# Altium schematic sheet MCU_AND_PW3.SchDoc
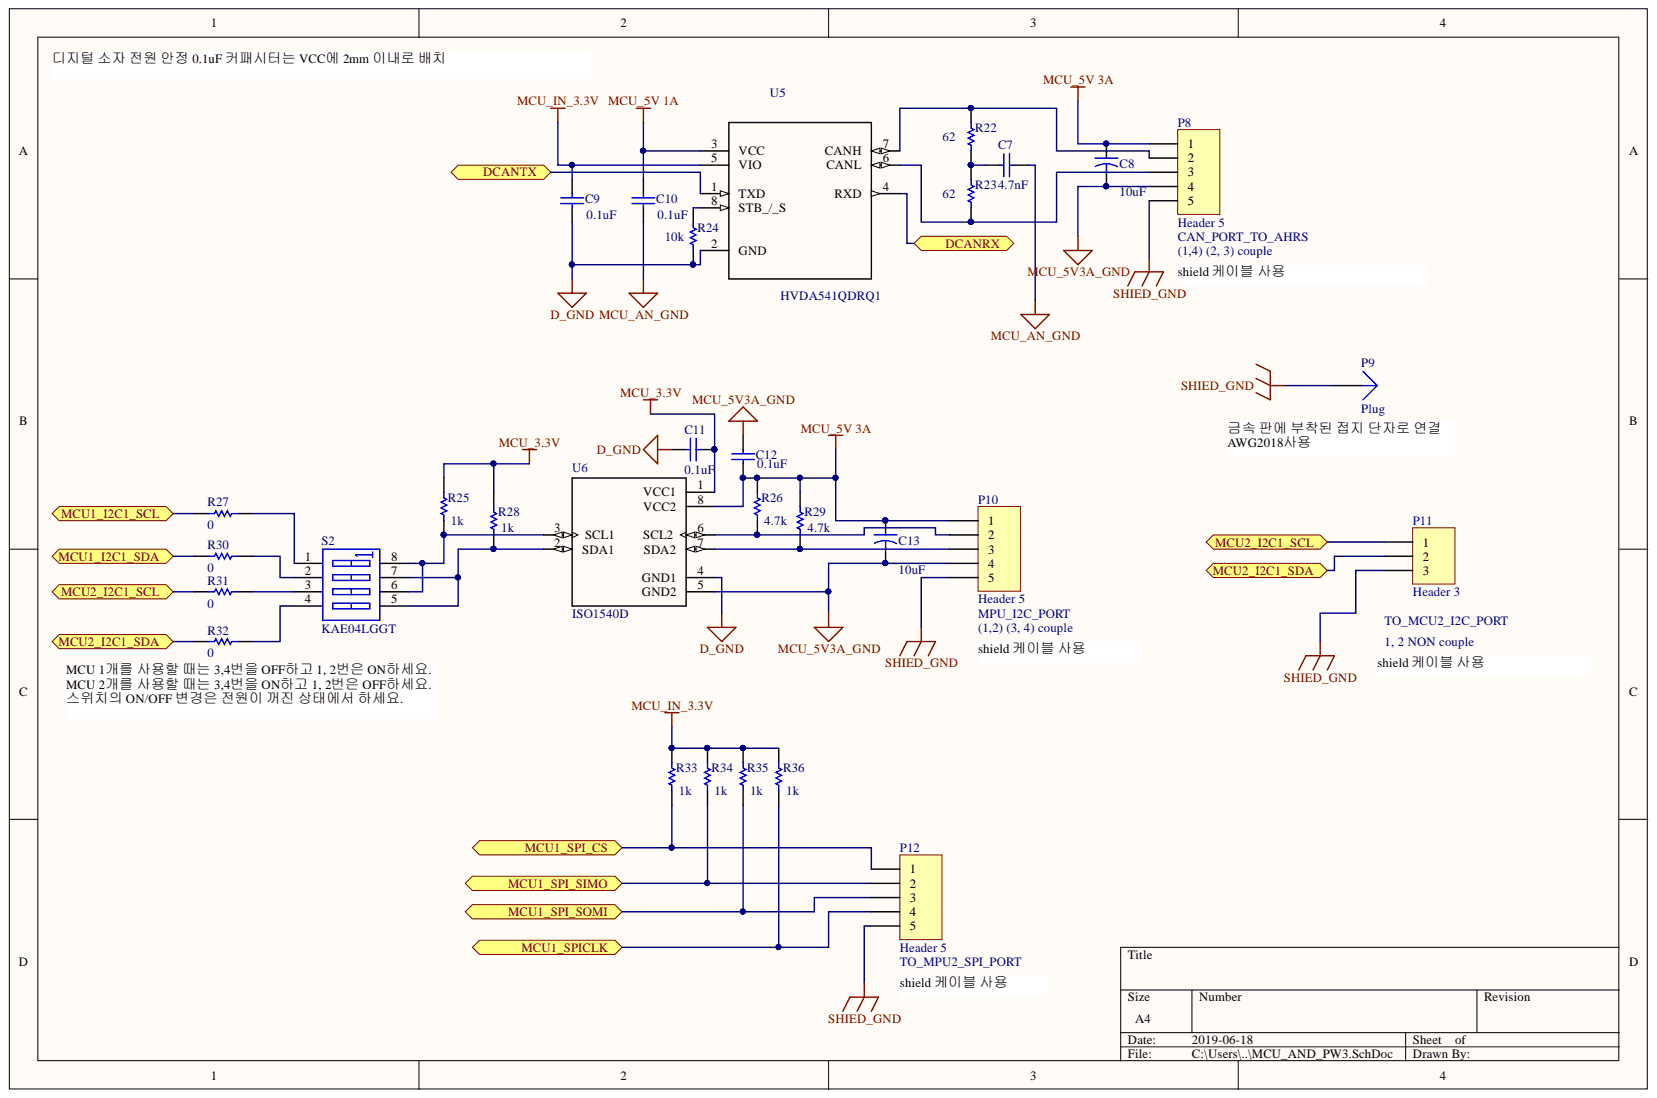
[diagram p0: MCU_AND_PW3.SchDoc]

## Parts
C7  value 4.7nF  [RAD-0.3]  (hidden comment: Cap)
C8  value 10uF  [CAPR5-4X5]  (hidden comment: Cap2)
C9  value 0.1uF  [RAD-0.3]  (hidden comment: Cap)
C10  value 0.1uF  [RAD-0.3]  (hidden comment: Cap)
C11  value 0.1uF  [RAD-0.3]  (hidden comment: Cap)
C12  value 0.1uF  [RAD-0.3]  (hidden comment: Cap)
C13  value 10uF  [CAPR5-4X5]  (hidden comment: Cap2)
P8  Header 5  [HDR1X5]
P9  Plug  [PIN1]
P10  Header 5  [HDR1X5]
P11  Header 3  [HDR1X3]
P12  Header 5  [HDR1X5]
R22  value 62  [AXIAL-0.3]  (hidden comment: Res1)
R23  value 62  [AXIAL-0.3]  (hidden comment: Res1)
R24  value 10k  [AXIAL-0.3]  (hidden comment: Res1)
R25  value 1k  [AXIAL-0.3]  (hidden comment: Res1)
R26  value 4.7k  [AXIAL-0.3]  (hidden comment: Res1)
R27  value 0  [AXIAL-0.3]  (hidden comment: Res1)
R28  value 1k  [AXIAL-0.3]  (hidden comment: Res1)
R29  value 4.7k  [AXIAL-0.3]  (hidden comment: Res1)
R30  value 0  [AXIAL-0.3]  (hidden comment: Res1)
R31  value 0  [AXIAL-0.3]  (hidden comment: Res1)
R32  value 0  [AXIAL-0.3]  (hidden comment: Res1)
R33  value 1k  [AXIAL-0.3]  (hidden comment: Res1)
R34  value 1k  [AXIAL-0.3]  (hidden comment: Res1)
R35  value 1k  [AXIAL-0.3]  (hidden comment: Res1)
R36  value 1k  [AXIAL-0.3]  (hidden comment: Res1)
S2  KAE04LGGT  [SO8_N]
U5  HVDA541QDRQ1  [*SOIC127P600X175-8N]
U6  ISO1540D  [*SOIC127P600X175-8N]

## Ports
DCANRX, DCANTX, MCU1_I2C1_SCL, MCU1_I2C1_SDA, MCU1_SPI_CS, MCU1_SPI_SIMO, MCU1_SPI_SOMI, MCU1_SPICLK, MCU2_I2C1_SCL, MCU2_I2C1_SDA

## Nets
D_GND: C9.1 C11.2 R24.1 U5.2(GND) U6.4(GND1)
MCU_3.3V: C11.1 R25.2 R28.2 U6.1(VCC1)
MCU_5V3A_GND: C8.2 C12.2 C13.2 P8.4 P10.4 U6.5(GND2)
MCU_5V 1A: C10.2 U5.3(VCC)
MCU_5V 3A: C8.1 C12.1 C13.1 P8.1 P10.1 R26.2 R29.2 U6.8(VCC2)
MCU_AN_GND: C7.1 C10.1
MCU_IN_3.3V: C9.2 R33.2 R34.2 R35.2 R36.2 U5.5(VIO)
SHIED_GND: P8.5 P9.1 P10.5 P11.3 P12.5
DCANRX: U5.4(RXD) ; port DCANRX
DCANTX: U5.1(TXD) ; port DCANTX
MCU1_I2C1_SCL: R27.2 ; port MCU1_I2C1_SCL
MCU1_I2C1_SDA: R30.2 ; port MCU1_I2C1_SDA
MCU1_SPI_CS: P12.1 R33.1 ; port MCU1_SPI_CS
MCU1_SPI_SIMO: P12.2 R34.1 ; port MCU1_SPI_SIMO
MCU1_SPI_SOMI: P12.3 R35.1 ; port MCU1_SPI_SOMI
MCU1_SPICLK: P12.4 R36.1 ; port MCU1_SPICLK
MCU2_I2C1_SCL: P11.1 R31.2 ; port MCU2_I2C1_SCL
MCU2_I2C1_SDA: P11.2 R32.2 ; port MCU2_I2C1_SDA
NetC7_2: C7.2 R22.1 R23.2
NetP8_2: P8.2 R22.2 U5.7(CANH)
NetP8_3: P8.3 R23.1 U5.6(CANL)
NetP10_2: P10.2 R26.1 U6.6(SCL2)
NetP10_3: P10.3 R29.1 U6.7(SDA2)
NetR24_2: R24.2 U5.8(STB_/_S)
NetR25_1: R25.1 S2.6 S2.8 U6.3(SCL1)
NetR27_1: R27.1 S2.1
NetR28_1: R28.1 S2.5 S2.7 U6.2(SDA1)
NetR30_1: R30.1 S2.2
NetR31_1: R31.1 S2.3
NetR32_1: R32.1 S2.4

## Library data of the parts
C7 C9-C12 = Cap: Capacitor; Component Kind: Standard; LatestRevisionDate: 17-Jul-2002; LatestRevisionNote: Re-released for DXP Platform.; Library Name: Miscellaneous Devices.IntLib; PackageDescription: Radial Cap, Thru-Hole; 2 Leads; 0.3 in Pin Spacing; PackageReference: RAD-0.3; Published: 8-Jun-2000; Signal Integrity: Cap; Simulation: CAP; Publisher: Altium Limited
C8 C13 = Cap2: Capacitor; Component Kind: Standard; Library Name: Miscellaneous Devices.IntLib; PackageDescription: Capacitor; 2 Leads; PackageReference: CAPR5-4X5; Published: 23-Sep-2002; Signal Integrity: Cap; Simulation: CAP; Publisher: Altium Limited
P8 P10 P12 = Header 5: Header, 5-Pin; Component Kind: Standard; LatestRevisionDate: 17-Jul-2002; LatestRevisionNote: Re-released for DXP Platform.; Library Name: Miscellaneous Connectors.IntLib; Signal Integrity: Connector; Publisher: Altium Limited
P11 = Header 3: Header, 3-Pin; Component Kind: Standard; LatestRevisionDate: 17-Jul-2002; LatestRevisionNote: Re-released for DXP Platform.; Library Name: Miscellaneous Connectors.IntLib; Signal Integrity: Connector; Publisher: Altium Limited
R22-R36 = Res1: Resistor; Component Kind: Standard; LatestRevisionDate: 17-Jul-2002; LatestRevisionNote: Re-released for DXP Platform.; Library Name: Miscellaneous Devices.IntLib; PackageDescription: Axial Device, Thru-Hole; 2 Leads; 0.3 in Pin Spacing; PackageReference: AXIAL-0.3; Published: 8-Jun-2000; Signal Integrity: Res; Simulation: RESISTOR; Publisher: Altium Limited
S2 = SW DIP-4: DIP Switch, 4 Position, SPST; Code_IPC: SOIC127P600-8; Code_JEDEC: MS-012-AA; Component Kind: Standard; LatestRevisionDate: 29-May-2009; LatestRevisionNote: IPC-7351 Footprint Added.; Library Name: Miscellaneous Devices.IntLib; PackageDescription: 8-Pin Small Outline Integrated Circuit 1.27 mm Pitch; PackageReference: SO8; PackageVersion: Dec-2002; Published: 24-Mar-1999; Simulation: dpsw4; Publisher: Altium Limited
U5 = HVDA541QDRQ1: Automotive Catalog 5-V CAN Transceiver with Standby mode, I/O Level Shifting and Supply Optimization 8-SOIC -40 to 125; MF: Texas Instruments; Availability: Unavailable; Component Kind: Standard; Library Name: HVDA541QDRQ1.SchLib; MP: HVDA541QDRQ1; Package: SOIC-8 Texas Instruments; Price: None; SnapEDA_Link: https://www.snapeda.com/parts/HVDA541QDRQ1/Texas%20Instruments/view-part/?ref=snap
U6 = ISO1540D: 2.5 kVrms Isolated Bidirectional Clock, Bidirectional I2C Isolators 8-SOIC -40 to 125; MF: Texas Instruments; Availability: Unavailable; Component Kind: Standard; Library Name: ISO1540D.SchLib; MP: ISO1540D; Package: SOIC-8 Texas Instruments; Price: None; SnapEDA_Link: https://www.snapeda.com/parts/ISO1540D/Texas%20Instruments/view-part/?ref=snap
P9 = Plug: Component Kind: Standard; Description: Plug; LatestRevisionDate: 17-Jul-2002; LatestRevisionNote: Re-released for DXP Platform.; Library Name: Miscellaneous Connectors.IntLib; Signal Integrity: Connector; Publisher: Altium Limited
